# Altium schematic sheet Power.SchDoc
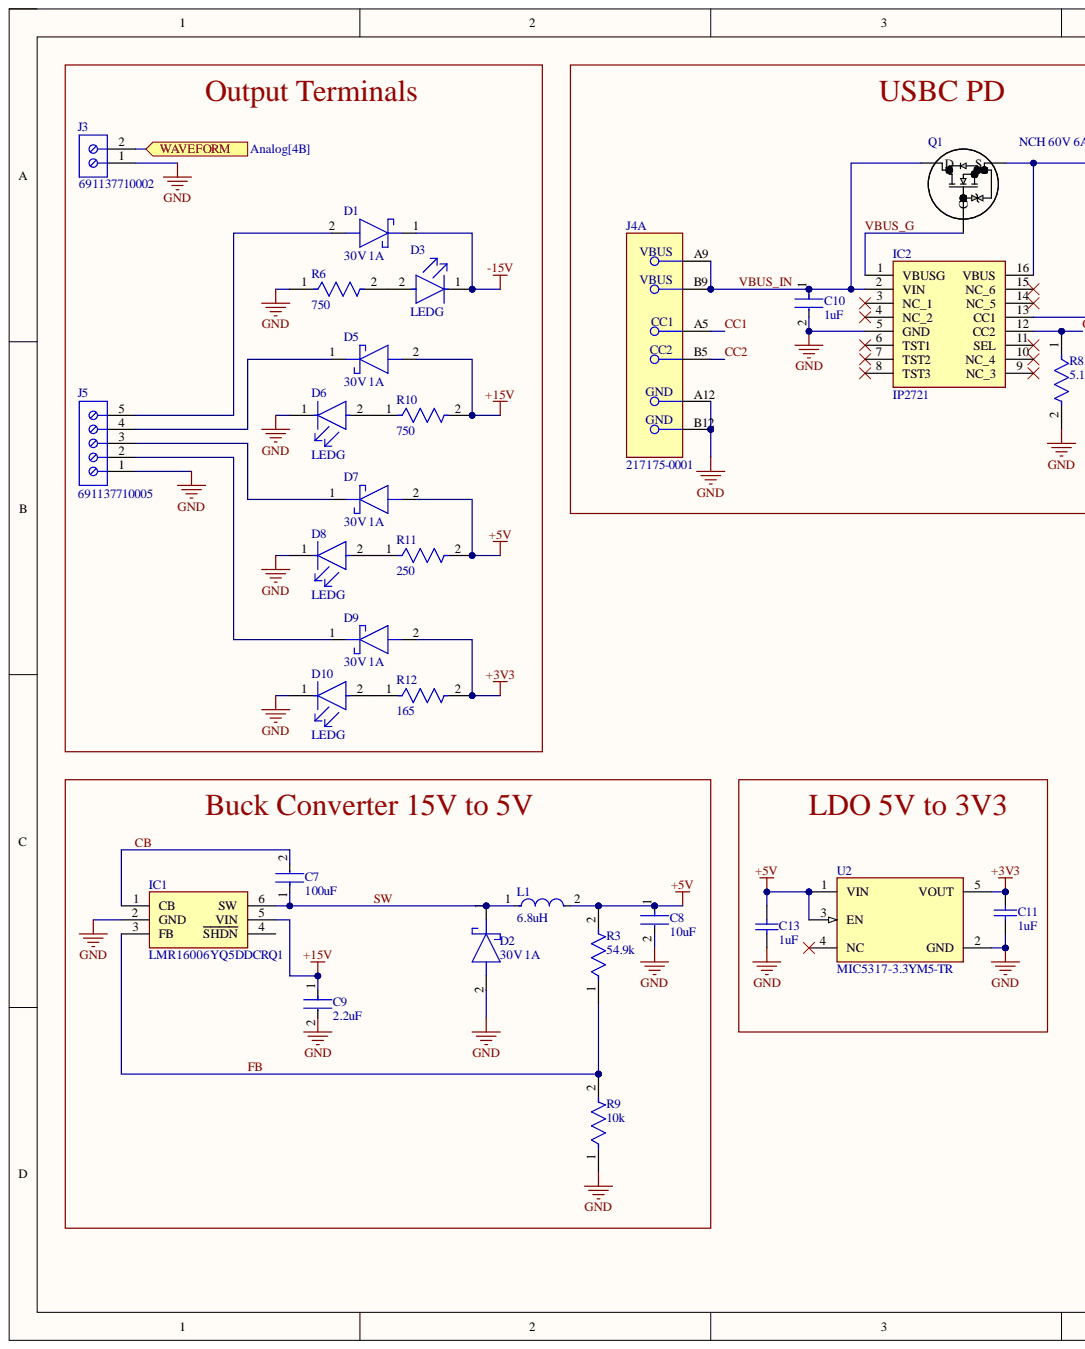
[diagram p0: Power.SchDoc, left]
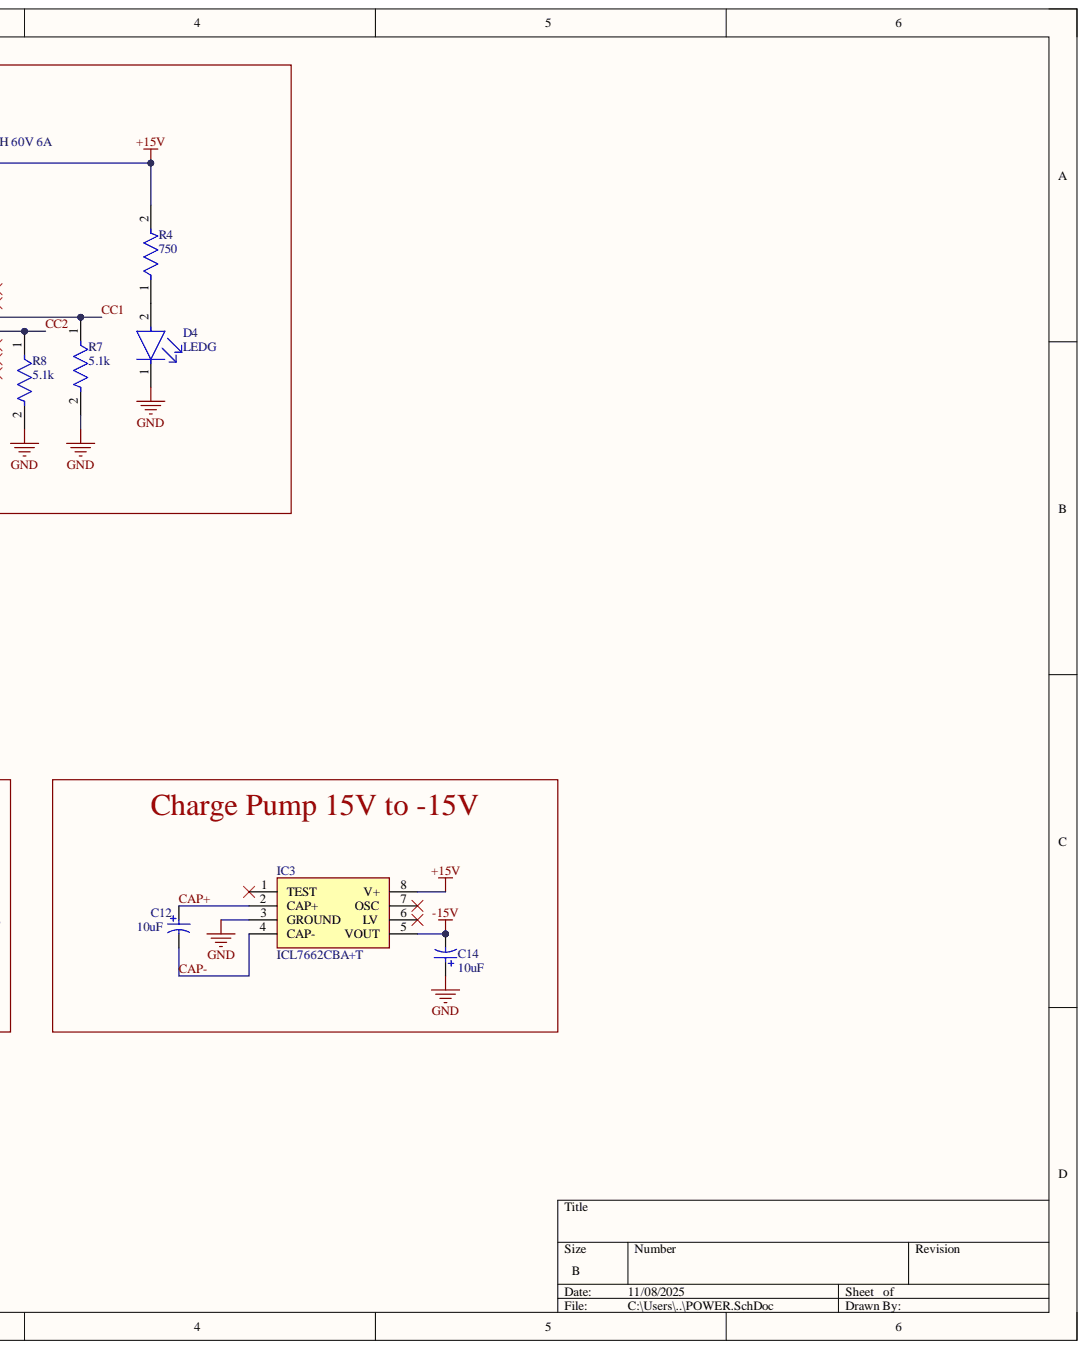
[diagram p1: Power.SchDoc, right]

## Parts
C7  100uF  [FP-C1206C-EB-MFG]
C8  10uF  [FP-CL21-IPC_A]
C9  2.2uF  [FP-C0402-BB-MFG]
C10  1uF  [FP-CGA5L-0_3-0_1-IPC_B]
C11  1uF  [CAPC1206(3216)130_N]
C12  10uF  [VISH-293D-E_L]
C13  1uF  [CAPC1206(3216)130_N]
C14  10uF  [VISH-293D-E_L]
D1  30V 1A  [1SS357TPH3F]
D2  30V 1A  [1SS357TPH3F]
D3  LEDG  [FP-LTST-C170GKT-MFG]
D4  LEDG  [FP-LTST-C170GKT-MFG]
D5  30V 1A  [1SS357TPH3F]
D6  LEDG  [FP-LTST-C170GKT-MFG]
D7  30V 1A  [1SS357TPH3F]
D8  LEDG  [FP-LTST-C170GKT-MFG]
D9  30V 1A  [1SS357TPH3F]
D10  LEDG  [FP-LTST-C170GKT-MFG]
IC1  LMR16006YQ5DDCRQ1  [SOT95P280X110-6N]
IC2  IP2721  [SOP65P640X120-16N]
IC3  ICL7662CBA+T  [SOIC127P600X175-8N]
J3  691137710002  [691137710002]
J4  217175-0001  [FP-217175-0001-MFG]  (part A)
J5  691137710005  [691137710005]
L1  6.8uH  [FP-LQH3NPH_ME-MFG]
Q1  NCH 60V 6A  [SOT-323F_2-2U1S_UFM_TOS]
R3  54.9k  [FP-TFCR0603-IPC_C]
R4  750  [FP-AT0805-IPC_C]
R6  750  [FP-RMCF0805-MFG]
R7  5.1k  [FP-TFCR0603-IPC_A]
R8  5.1k  [FP-TFCR0603-IPC_A]
R9  10k  [FP-AT0805-IPC_C]
R10  750  [FP-RMCF0805-MFG]
R11  250  [FP-RMCF0805-MFG]
R12  165  [FP-TFCR0603-IPC_B]
U2  MIC5317-3.3YM5-TR  [FP-SOT23-5LD-PL-1-MFG]

## Ports
WAVEFORM

## Nets
+3V3: C11.2 D9.2 R12.2 U2.5(VOUT)
+5V: C8.1 C13.2 D7.2 L1.2 R3.2 R11.2 U2.1(VIN) U2.3(EN)
+15V: C9.1 D5.2 IC1.5(VIN) IC2.16(VBUS) IC3.8(V+) Q1.2 R4.2 R10.2
-15V: C14.2 D1.1 D3.1 IC3.5(VOUT)
GND: C8.2 C9.2 C10.2 C11.1 C13.1 C14.1 D2.2 D4.1 D6.1 D8.1 D10.1 IC1.2(GND) IC2.5(GND) IC3.3(GROUND) J3.1 J4.A12 J4.B12 J5.1 R6.1 R7.2 R8.2 R9.1 U2.2(GND)
CAP+: C12.1 IC3.2(CAP+)
CAP-: C12.2 IC3.4(CAP-)
CB: C7.2 IC1.1(CB)
CC1: IC2.13(CC1) J4.A5 R7.1
CC2: IC2.12(CC2) J4.B5 R8.1
FB: IC1.3(FB) R3.1 R9.2
SW: C7.1 D2.1 IC1.6(SW) L1.1
VBUS_G: IC2.1(VBUSG) Q1.1
VBUS_IN: C10.1 IC2.2(VIN) J4.A9 J4.B9 Q1.3
WAVEFORM: J3.2 ; port WAVEFORM
NetD1_2: D1.2 J5.5
NetD3_2: D3.2 R6.2
NetD4_2: D4.2 R4.1
NetD5_1: D5.1 J5.4
NetD6_2: D6.2 R10.1
NetD7_1: D7.1 J5.3
NetD8_2: D8.2 R11.1
NetD9_1: D9.1 J5.2
NetD10_2: D10.2 R12.1

Not connected: IC1 4(SHDN); IC2 3(NC_1) 4(NC_2) 6(TST1) 7(TST2) 8(TST3) 9(NC_3) 10(NC_4) 11(SEL) 14(NC_5) 15(NC_6); IC3 1(TEST) 6(LV) 7(OSC); U2 4(NC)

## Library data of the parts
C7 = CMP-03020-014955-1: C1206 0.10 μF C0G 10.00% 25 V X7R; Manufacturer: KEMET; Manufacturer Part Number: C1206C104K3GACTU; Automotive Grade: Grade 0; Capacitance: 0.10 μF; Material: Ceramic; Temperature Coefficient: 15%; Tolerance: 0.1; Value: 0.10 μF; Voltage Rating: 25 V; Automotive: Yes; Category: Cap; Component Kind: Standard; ComponentLink2Description: Datasheet; ComponentLink2URL: https://content.kemet.com/datasheets/KEM_C1007_X8R_ULTRA_150C_SMD.pdf; Device Class L1: Passive Components; Device Class L2: Capacitors; Device Class L3: Ceramic Capacitors; Height: 0.88mm; Ipc Land Pattern Name: CAPC320160X78; Lead Free: Yes; Library Name: Altium Content Vault; Mouser Description: Multilayer Ceramic Capacitors MLCC - SMD/SMT 25volt 0.1uF 10% C0G; Octopart: https://octopart.com/c1206c104k3gactu-kemet-12901041; Package: C1206C-EB; RoHS: TRUE; Temperature: -55°C to +150°C; Temperature Characteristic: C0G; Temperature Range High: +150°C; Temperature Range Low: -55°C
C8 = CMP-13271-002975-1: CL21 Series 0805 10uF 25V ±10% Tolerance X5R Multilayer Ceramic Chip Capacitor; Capacitance: 10uF; Case Code (Imperial): 0805; Case Code (Metric): 2012; Case/Package: 0805; Component Kind: Standard; Depth: 1.25mm; Dielectric: X5R; Height: 1.25mm; Length: 2mm; Library Name: Altium Content Vault; Max Operating Temperature: 85°C; Min Operating Temperature: -55°C; Mount: Surface Mount; Package Quantity: 1; Packaging: Tape and Reel; Radiation Hardening: No; Thickness: 0.057inch; Tolerance: 10%; Voltage Rating: 25V; Width: 0.049inch
C9 = CMP-1034-01736-3: C0402 2,200 pF C0G 0.02 25 V; Manufacturer: Kemet; Manufacturer Part Number: C0402C222G3GACTU; Material: Ceramic; Tolerance: 0.02; Voltage Rating: 25 V; Automotive: No; Capacitance: 2,200 pF; Category: Cap; Component Kind: Standard; ComponentLink2Description: Datasheet; ComponentLink2URL: https://content.kemet.com/datasheets/KEM_C1002_X7R_SMD.pdf; Device Class L1: Passive Components; Device Class L2: Capacitors; Device Class L3: Ceramic Capacitors; Height: 0.55mm; Ipc Land Pattern Name: CAPC10050X50; Lead Free: Yes; Library Name: Altium Content Vault; Mouser Description: Multilayer Ceramic Capacitors MLCC - SMD/SMT 25volts 2200pF 2% C0G 0402; Octopart: https://octopart.com/c0402c222g3gactu-kemet-87368565; Package: C0402-BB; RoHS: TRUE; Temperature: -55°C to +125°C; Temperature Characteristic: C0G; Temperature Range High: +125°C; Temperature Range Low: -55°C; Value: 2,200 pF
C10 = CMP-08246-000049-1: Cap Ceramic 1uF 25V X7R 20% Pad SMD 1206 +125°C Automotive T/R; Capacitance: 1uF; Case Code (Imperial): 1206; Case Code (Metric): 3216; Case/Package: 1206; Component Kind: Standard; Dielectric: X7R; Height: 1.6mm; Length: 0.126inch; Library Name: Altium Content Vault; Max Operating Temperature: 125°C; Min Operating Temperature: -55°C; Mount: Surface Mount; Package Quantity: 2000; Packaging: Tape and Reel; Ratings: AEC-Q200; Thickness: 0.063inch; Tolerance: 20%; Voltage Rating: 25V; Voltage Rating (DC): 25V; Weight: 0.000571oz; Width: 1.6mm
C11 C13 = CMP-1037-04671-1: CAP 1uF 10V ±5% 1206 (3216 Metric) Thickness 1.3mm SMD; Case-EIA: 1206; Case-Metric: 3216; Tolerance: Â±5%; Component Kind: Standard; Library Name: Altium Content Vault; Max Thickness: 1.3 mm; Rated Voltage: 10 V; Technology: SMT; Value: 1uF
C12 C14 = CMP-2000-05556-1: Tantalum Capacitor, 10 uF, +/- 10%, 50 V, -55 to 125 degC, 2-Pin SMD (7343-43), RoHS, Tape and Reel; Manufacturer: Vishay; Capacitance: 10000000pF; Case Style: Molded; DCL: 5uA; DELETED: Compliant; DF: 6%; ESR: 0.8ohm; Insulation: NotRequired; Lead Spacing (nom): NotRequiredmm; Polarity: Polar; Product Depth (mm): 4.3mm; Product Height (mm): 4mm; Product Length (mm): 7.3mm; Rad Hardened: No; Voltage: 50VDC; Case Code: E; Component Kind: Standard; Construction: SMTChip; Datasheet URL: http://www.vishay.com/docs/40002/293d.pdf; Datasheet Version: 08/2016; Failure Rate: NotRequired; Length W/Weld: NotRequiredmm; Length W/Weld (max): NotRequiredmm; Library Name: Altium Content Vault; Manufacturer URL: http://www.vishay.com/; Mounting Style: SurfaceMount; Mounting Technology: SurfaceMount; Operating Temp Range: -55Cto125C; Package / Case: 7343-43; Package Description: 2-Pin Surface Mount Device, Body 7.3 x 4.3 mm; Package Reference: 293D-E; Packaging: TapeandReel; Product Diameter (mm): NotRequiredmm; Seal: NotRequired; Seated Plane Height: NotRequiredmm; Tolerance (+ or -): 10%; Wire Form: NotRequired
D1 D2 D5 D7 D9 = CUS10S30,H3F: Schottky Diode; Manufacturer_Name: Toshiba; Arrow Part Number: CUS10S30,H3F; Arrow Price/Stock: https://www.arrow.com/en/products/cus10s30h3f/toshiba?utm_currency=USD&region=nac; Component Kind: Standard; Datasheet Link: http://toshiba.semicon-storage.com/info/docget.jsp?did=14077&prodName=CUS10S30; Height: 1mm; Manufacturer_Part_Number: CUS10S30,H3F; Mouser Part Number: 757-CUS10S30H3F; Mouser Price/Stock: https://www.mouser.co.uk/ProductDetail/Toshiba/CUS10S30H3F?qs=cW4DzVrAanO4Xm6ySrHr7g%3D%3D
D3 D4 D6 D8 D10 = CMP-1674-00002-6: LED GREEN CLEAR SMD; Dominant Wavelength: 569nm; Forward Current: 30mA; Forward Voltage: 2.1V; Lens Color: Colorless; Lens Style: Diffused; Luminous Intensity: 6mcd; Number of Elements: 1; Power Dissipation: 100mW; Reverse Voltage: 5V; Test Current: 10mA; Viewing Angle: 130°; Wavelength: 565nm; Case Code (Imperial): 0805; Case Code (Metric): 2012; Case/Package: 0805; Color: Green; Component Kind: Standard; Depth: 1.25mm; Height: 1.1mm; Illumination Color: Green; Lead Free: Lead Free; Length: 2mm; Lens Transparency: Clear; Library Name: Altium Content Vault; Max Operating Temperature: 85°C; Min Operating Temperature: -55°C; Mount: Surface Mount; Number of LEDs: 1; Number of Pins: 2; Package Quantity: 1; Packaging: Tape and Reel; Power Rating: 100mW; Radiation Hardening: No; Voltage: 5V; Width: 1.25mm
IC1 = LMR16006YQ5DDCRQ1: Integrated Circuit; Manufacturer_Name: Texas Instruments; Arrow Part Number: LMR16006YQ5DDCRQ1; Arrow Price/Stock: https://www.arrow.com/en/products/lmr16006yq5ddcrq1/texas-instruments?utm_currency=USD&region=nac; Component Kind: Standard; Datasheet Link: http://www.ti.com/lit/gpn/lmr16006y-q1; Height: 1.1mm; Manufacturer_Part_Number: LMR16006YQ5DDCRQ1; Mouser Part Number: 595-MR16006YQ5DDCRQ1; Mouser Price/Stock: https://www.mouser.co.uk/ProductDetail/Texas-Instruments/LMR16006YQ5DDCRQ1?qs=XJu%252BLGjWfSDhOUpGCmjN4w%3D%3D
IC2 = IP2721: Integrated Circuit; Manufacturer_Name: INJOINIC; Component Kind: Standard; Datasheet Link: https://datasheet.lcsc.com/szlcsc/2006111335_INJOINIC-IP2721_C603176.pdf; Height: 1.2mm; Manufacturer_Part_Number: IP2721
IC3 = ICL7662CBA+T: Integrated Circuit; Manufacturer_Name: Analog Devices; Arrow Part Number: ICL7662CBA+T; Arrow Price/Stock: https://www.arrow.com/en/products/icl7662cbat/analog-devices?region=nac; Component Kind: Standard; Datasheet Link: https://datasheet.datasheetarchive.com/originals/dk/DKDS-7/135327.pdf; Height: 1.75mm; Manufacturer_Part_Number: ICL7662CBA+T; Mouser Part Number: 700-ICL7662CBAT; Mouser Price/Stock: https://www.mouser.co.uk/ProductDetail/Analog-Devices-Maxim-Integrated/ICL7662CBA%2bT?qs=Mqkh4jHMT8yEwIZBI%252Brh9g%3D%3D
J3 = CMP-1502-03324-2: NexarPartId: 78871135; Current Rating: 16A; Number of Contacts: 2; Voltage Rating: 300V; Component Kind: Standard; Contact Material: Nickel, Tin; Height: 11.6mm; Lead Pitch: 5mm; Library Name: Altium Content Vault; Max Operating Temperature: 120°C; Min Operating Temperature: -30°C; Number of Pins: 2; Number of Rows: 1; Packaging: Box; RoHS: Non-Compliant
J4 = CMP-04767-000026-1: CONN RCP USB2.0 C 6+18P SMD RA; Component Kind: Standard; Library Name: Altium Content Vault
J5 = CMP-1502-03327-2: NexarPartId: 78871141; Component Kind: Standard; Lead Pitch: 5mm; Library Name: Altium Content Vault; Number of Pins: 5; Packaging: Box; RoHS: Non-Compliant
L1 = CMP-06042-015081-1: Wire Wound Ferrite Inductor for Power Lines For Automotive 6.8μH ±20% 0.168Ω 1450mA 1212; Component Kind: Standard; Library Name: Altium Content Vault
Q1 = SSM3K341TU,LF: N-ch MOSFET, 60 V, 6.0 A, 0.036 Î©@10V, SOT-323F(UFM); Manufacturer_Name: Toshiba Electronic Devices & Storage Corp.; Component Kind: Standard; Datasheet: https://toshiba.semicon-storage.com/info/docget.jsp?did=56016&prodName=SSM3K341TU; Library Name: Connectors.SchLib; Manufacturer_Part_Number: SSM3K341TU,LF; Copyright: Copyright (C) 2025 Ultra Librarian. All rights reserved.
R3 = CMP-250906-169285-1: RES Thin Film,  54.9kΩ, 0.25%, 0.1W, 50ppm/°C, 0603; Component Kind: Standard; Library Name: Altium Content Vault
R4 R9 = CMP-03412-048709-1: Thin Film Chip Resistors Automotive Grade 10kΩ 0.1% 50ppm/°C 0805; Component Kind: Standard; Library Name: Altium Content Vault
R6 R10 = CMP-26527-002688-1: 6.8kΩ ±5% 0.125W 0805 Thick Film Chip Resistor AEC-Q200 compliant; Max Power Dissipation: 125mW; Resistance: 6.8kR; Temperature Coefficient: 200ppm/°C; Case Code (Imperial): 0805; Case Code (Metric): 2012; Case/Package: 0805; Component Kind: Standard; Construction: Rectangular; Contact Plating: Tin; Depth: 1.25mm; Features: Automotive AEC-Q200; Height: 0.026inch; Lead Free: Lead Free; Length: 0.079inch; Library Name: Altium Content Vault; Max Operating Temperature: 155°C; Military Standard: Not; Min Operating Temperature: -55°C; Mount: Surface Mount; Number of Terminations: 2; Packaging: Tape and Reel; Power Rating: 125mW; Radiation Hardening: No; Resistor Type: Thick Film; RoHS Compliant: Yes; Series: RMCF; Size Code: 0805; Tolerance: 5%; Width: 0.049inch
R7 R8 = CMP-250906-167103-1: RES Thin Film,  5.1kΩ, 0.25%, 0.1W, 25ppm/°C, 0603; Component Kind: Standard; Library Name: Altium Content Vault
R11 = CMP-26527-002688-1: 0805 250 Ohms 0.25W; Max Power Dissipation: 125mW; Resistance: 6.8kR; Temperature Coefficient: 200ppm/°C; Tolerance: 5%; Case Code (Imperial): 0805; Case Code (Metric): 2012; Case/Package: 0805; Component Kind: Standard; Construction: Rectangular; Contact Plating: Tin; Depth: 1.25mm; Features: Automotive AEC-Q200; Height: 0.026inch; Lead Free: Lead Free; Length: 0.079inch; Library Name: Altium Content Vault; Max Operating Temperature: 155°C; Military Standard: Not; Min Operating Temperature: -55°C; Mount: Surface Mount; Number of Terminations: 2; Packaging: Tape and Reel; Power Rating: 125mW; Radiation Hardening: No; Resistor Type: Thick Film; RoHS Compliant: Yes; Series: RMCF; Size Code: 0805; Width: 0.049inch
R12 = CMP-250906-226900-1: RES Thin Film,  620Ω, 0.25%, 0.1W, 25ppm/°C, 0603; Component Kind: Standard; Library Name: Altium Content Vault
U2 = CMP-06324-000116-1: IC REG LINEAR 3.3V 150MA SOT23-5; Dropout Voltage: 155mV; Max Input Voltage: 6V; Min Current Limit: 200mA; Min Input Voltage: 2.5V; Number of Outputs: 1; Power Supply Rejection Ratio (PSRR): 70dB; Case/Package: SOT-23-5; Component Kind: Standard; Library Name: Altium Content Vault; Max Operating Temperature: 125°C; Min Operating Temperature: -40°C; Mount: Surface Mount; Number of Regulators: 1; Output Current: 150mA; Output Voltage: 3.3V; Package Quantity: 3000; Packaging: Tape and Reel; RoHS Compliant: No; Series: -
